# Altium schematic sheet ICWSHLP2_Head_2x2_ASIC2.SchDoc(ICWSHLP2_Head_2x2_ASIC2)
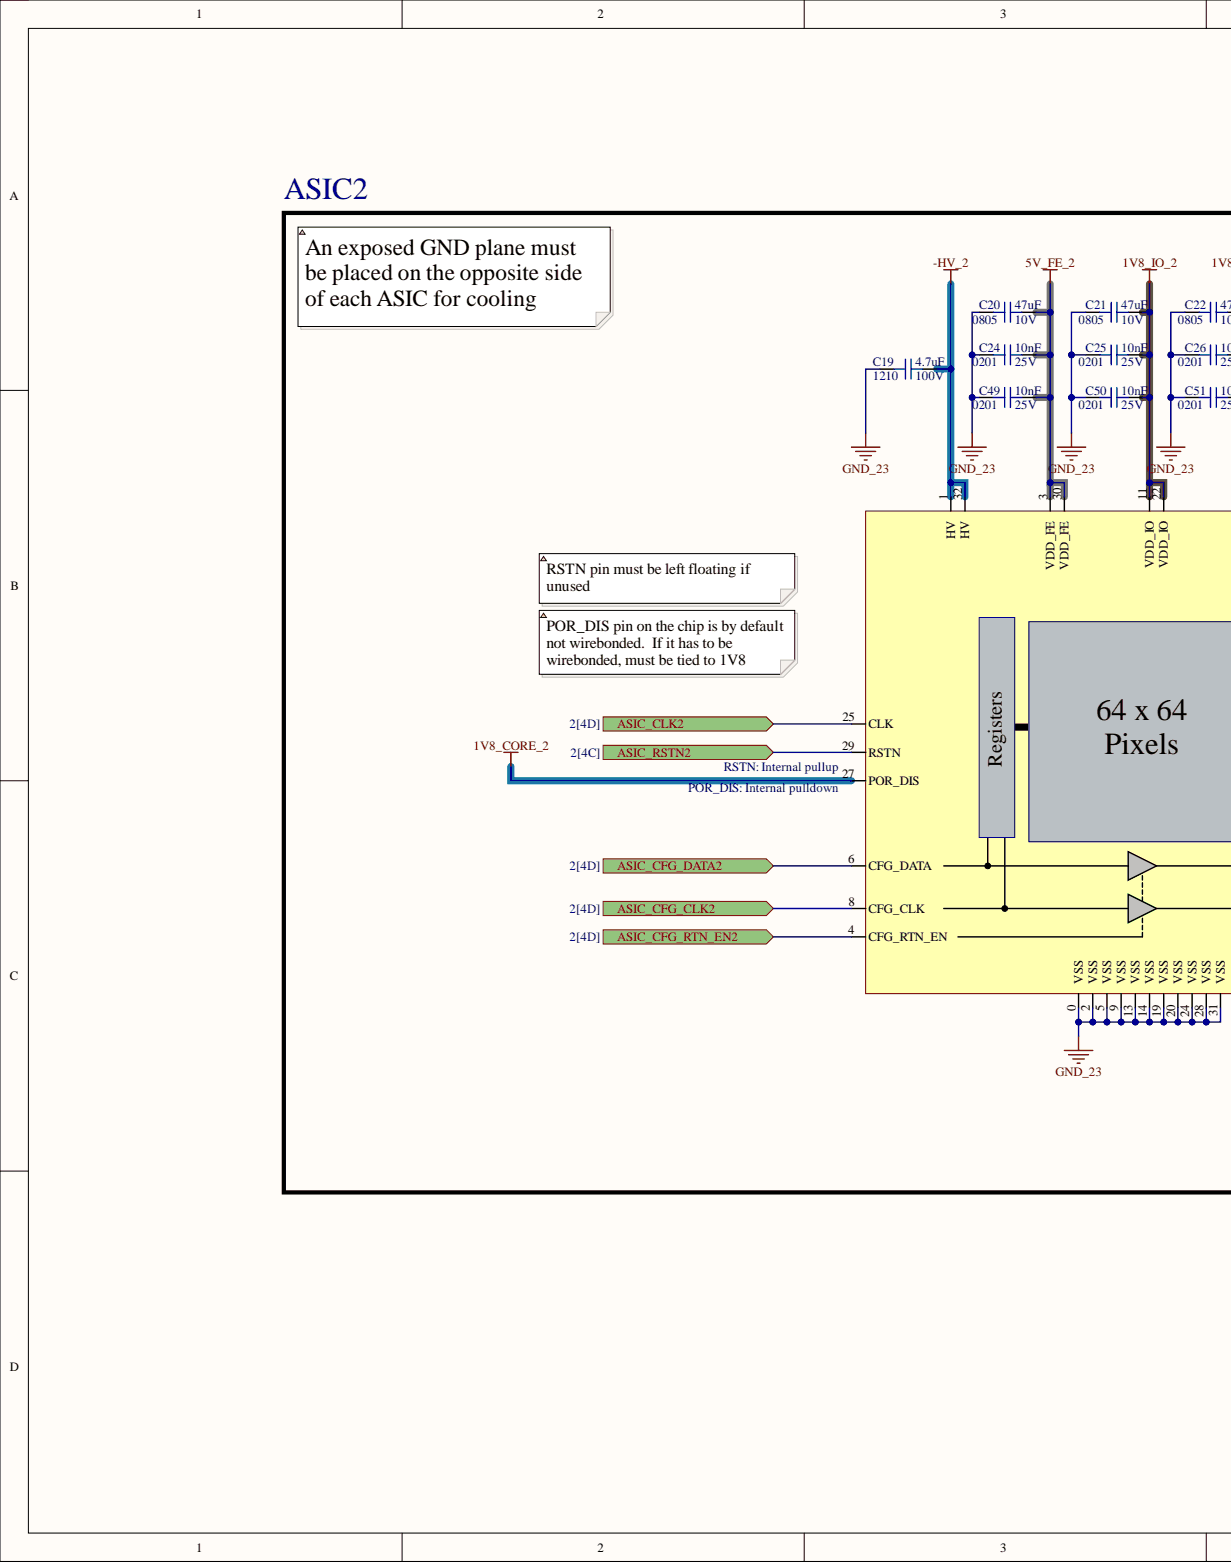
[diagram p0: ICWSHLP2_Head_2x2_ASIC2.SchDoc, left]
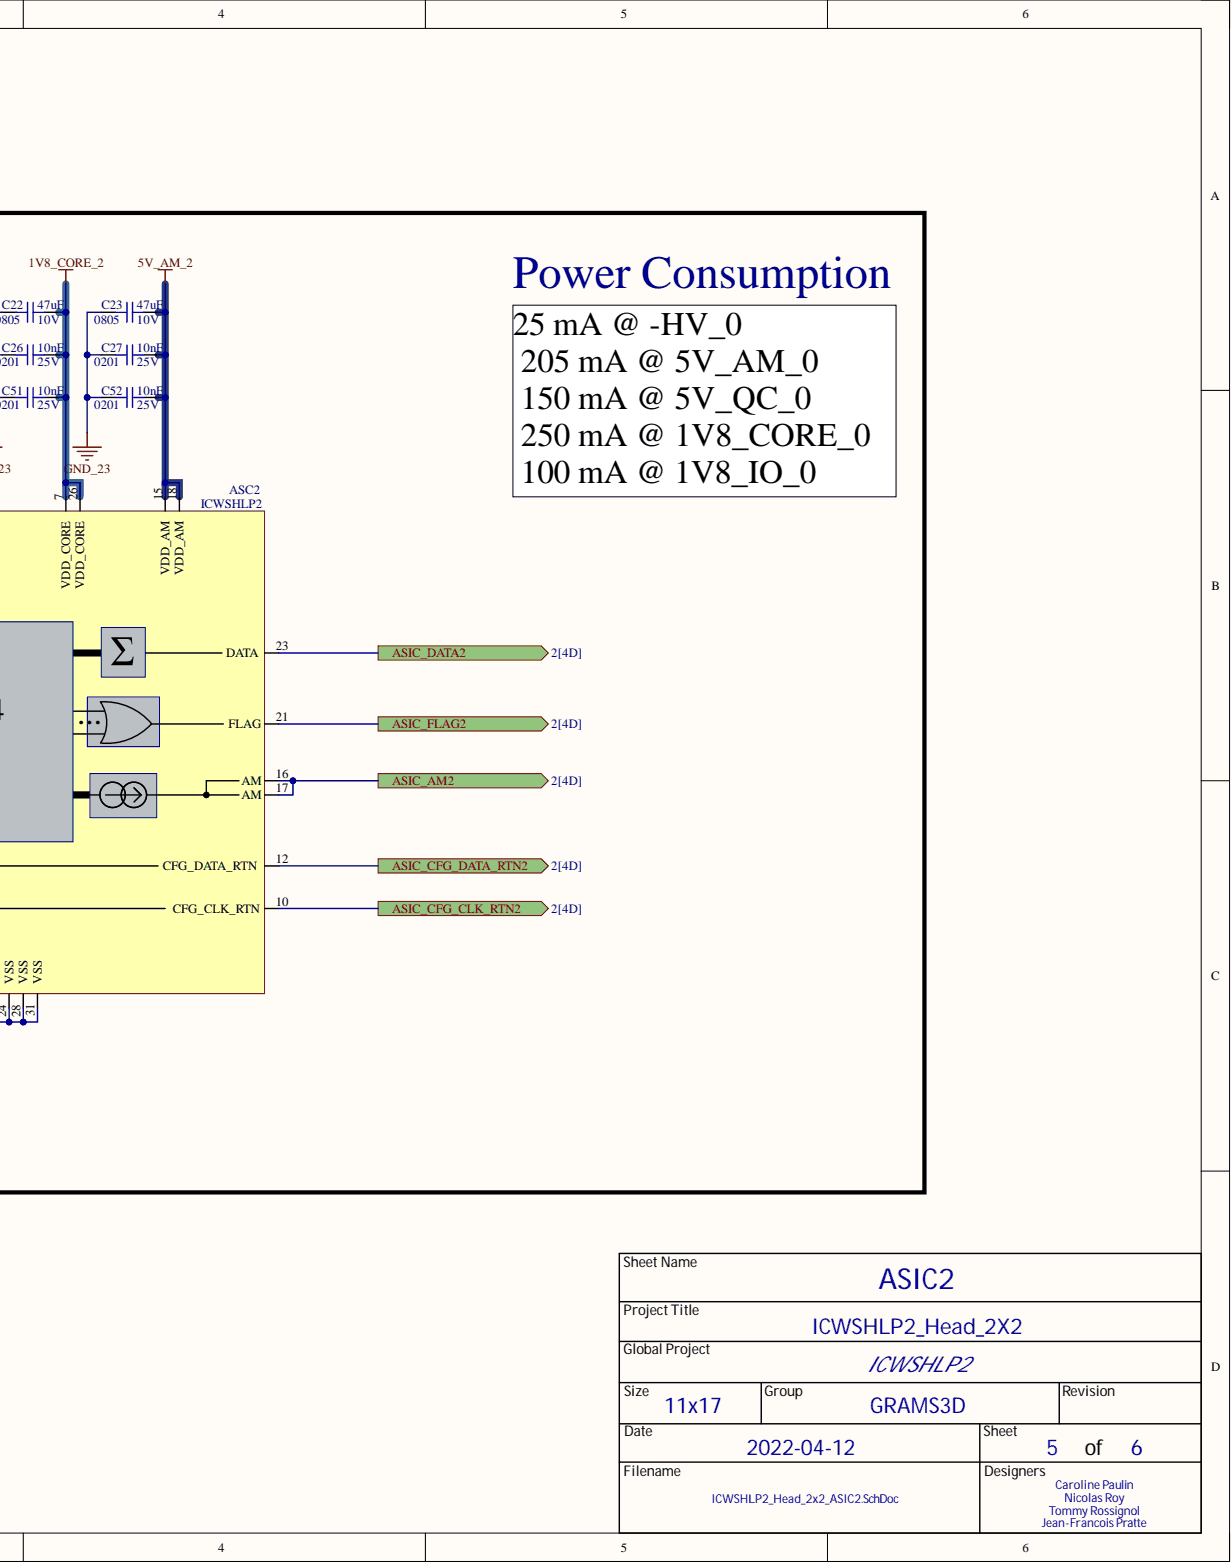
[diagram p1: ICWSHLP2_Head_2x2_ASIC2.SchDoc, right]

## Parts
ASC2  ICWSHLP2  [ASC_ICWSHLP2]
C19  value 4.7uF  Package: 1210  Voltage Rating: 100V  [CAP_CER_1210]  (hidden comment: GCM32DC72A475KE02L)
C20  value 47uF  Package: 0805  Voltage Rating: 10V  [CAP_CER_0805]  (hidden comment: GRM21BR61A476ME15L)
C21  value 47uF  Package: 0805  Voltage Rating: 10V  [CAP_CER_0805]  (hidden comment: GRM21BR61A476ME15L)
C22  value 47uF  Package: 0805  Voltage Rating: 10V  [CAP_CER_0805]  (hidden comment: GRM21BR61A476ME15L)
C23  value 47uF  Package: 0805  Voltage Rating: 10V  [CAP_CER_0805]  (hidden comment: GRM21BR61A476ME15L)
C24  value 10nF  Package: 0201  Voltage Rating: 25V  [CAP_CER_0201]  (hidden comment: TMK063B7103KP-F)
C25  value 10nF  Package: 0201  Voltage Rating: 25V  [CAP_CER_0201]  (hidden comment: TMK063B7103KP-F)
C26  value 10nF  Package: 0201  Voltage Rating: 25V  [CAP_CER_0201]  (hidden comment: TMK063B7103KP-F)
C27  value 10nF  Package: 0201  Voltage Rating: 25V  [CAP_CER_0201]  (hidden comment: TMK063B7103KP-F)
C49  value 10nF  Package: 0201  Voltage Rating: 25V  [CAP_CER_0201]  (hidden comment: TMK063B7103KP-F)
C50  value 10nF  Package: 0201  Voltage Rating: 25V  [CAP_CER_0201]  (hidden comment: TMK063B7103KP-F)
C51  value 10nF  Package: 0201  Voltage Rating: 25V  [CAP_CER_0201]  (hidden comment: TMK063B7103KP-F)
C52  value 10nF  Package: 0201  Voltage Rating: 25V  [CAP_CER_0201]  (hidden comment: TMK063B7103KP-F)

## Ports
ASIC_AM2, ASIC_CFG_CLK2, ASIC_CFG_CLK_RTN2, ASIC_CFG_DATA2, ASIC_CFG_DATA_RTN2, ASIC_CFG_RTN_EN2, ASIC_CLK2, ASIC_DATA2, ASIC_FLAG2, ASIC_RSTN2

## Nets
1V8_CORE_2: ASC2.7(VDD_CORE) ASC2.26(VDD_CORE) ASC2.27(POR_DIS) C22.2 C26.1 C51.1
1V8_IO_2: ASC2.11(VDD_IO) ASC2.22(VDD_IO) C21.2 C25.1 C50.1
5V_AM_2: ASC2.15(VDD_AM) ASC2.18(VDD_AM) C23.2 C27.1 C52.1
5V_FE_2: ASC2.3(VDD_FE) ASC2.30(VDD_FE) C20.2 C24.1 C49.1
-HV_2: ASC2.1(HV) ASC2.32(HV) C19.1
GND_23: ASC2.0(VSS) ASC2.2(VSS) ASC2.5(VSS) ASC2.9(VSS) ASC2.13(VSS) ASC2.14(VSS) ASC2.19(VSS) ASC2.20(VSS) ASC2.24(VSS) ASC2.28(VSS) ASC2.31(VSS) C19.2 C20.1 C21.1 C22.1 C23.1 C24.2 C25.2 C26.2 C27.2 C49.2 C50.2 C51.2 C52.2
ASIC_AM2: ASC2.16(AM) ASC2.17(AM) ; port ASIC_AM2
ASIC_CFG_CLK2: ASC2.8(CFG_CLK) ; port ASIC_CFG_CLK2
ASIC_CFG_CLK_RTN2: ASC2.10(CFG_CLK_RTN) ; port ASIC_CFG_CLK_RTN2
ASIC_CFG_DATA2: ASC2.6(CFG_DATA) ; port ASIC_CFG_DATA2
ASIC_CFG_DATA_RTN2: ASC2.12(CFG_DATA_RTN) ; port ASIC_CFG_DATA_RTN2
ASIC_CFG_RTN_EN2: ASC2.4(CFG_RTN_EN) ; port ASIC_CFG_RTN_EN2
ASIC_CLK2: ASC2.25(CLK) ; port ASIC_CLK2
ASIC_DATA2: ASC2.23(DATA) ; port ASIC_DATA2
ASIC_FLAG2: ASC2.21(FLAG) ; port ASIC_FLAG2
ASIC_RSTN2: ASC2.29(RSTN) ; port ASIC_RSTN2

## Library data of the parts
ASC2 = ASC_ICWSHLP2: Rev 2. CMOS SPAD Readout for 3D PDC in Low Power Applications.; Manufacturer #1: TSMC 180 nm BCD; GRAMS Lib Spec: 2.7; Magnetic Material: No; Polarized: Yes; Voltage Rating: 1.8V/5.0V; Assembly Type: Wirebonding; Component Kind: Standard; Library Name: GRAMS_MASTER_LIB_SCH.SchLib; Package: Bare Die; Part Number #1: ICWSHLP2; Supplier 1: TSMC; Supplier Part Number 1: ICWSHLP2; Temperature: -200 to 125 degC; CreatedBy/Date: Nicolas Roy/24 sept. 2018; VerifiedBy: Nicolas Roy
C19 = CAP_CER_GCM32DC72A475KE02L: CAP CER 4.7UF 100V X7S 1210; Manufacturer #1: Murata Electronics; Cryo: UNKNOWN; GRAMS Lib Spec: 2.8; Polarized: NO; Tolerance: 5%; Assembly Type: SMD; Component Kind: Standard; HelpURL: https://search.murata.co.jp/Ceramy/image/img/A01X/G101/ENG/GCM32DC72A475KE02-01.pdf; Magnetic Material: UNKNOWN; Part Number #1: GCM32DC72A475KE02L; Solder Paste Type: UNKNOWN; Stencil Thickness (Recommended): UNKNOWN; Supplier 1: Digi-Key; Supplier Part Number 1: 490-14484-1-ND; Temperature: -55°C ~ 125°C; CreatedBy/Date: Thomas G-C 2021-07-01; Library Name: Lib_temp_Thomas_GC.SchLib
C20-C23 = CAP_CER_GRM21BR61A476ME15L: CAP - CER 47uF 20% 10V X5R - 0805; Manufacturer #1: Murata; Cryo: UNKNOWN; GRAMS Lib Spec: 2.8; Polarized: No; Assembly Type: SMD; Component Kind: Standard; HelpURL: http://search.murata.co.jp/Ceramy/image/img/A01X/G101/ENG/GRM21BR61A476ME15-01.pdf; Library Name: GRAMS_MASTER_LIB_SCH.SchLib; Magnetic Material: UNKNOWN; Part Number #1: GRM21BR61A476ME15L; Solder Paste Type: UNKNOWN; Stencil Thickness (Recommended): UNKNOWN; Supplier 1: Digi-Key; Supplier Part Number 1: 490-9961-1-ND; Temperature: -55°C ~ 85°C; Tolerance: 20%; CreatedBy/Date: Joel Lemay 2018-06-19; VerifiedBy: Caroline Paulin - 7 sept 2018
C24-C27 C49-C52 = CAP_CER_TMK063B7103KP-F: CAP - CER 10nF 10% 25V X7R - 0201; Manufacturer #1: Taiyo Yuden; Cryo: UNKNOWN; GRAMS Lib Spec: 2.8; Polarized: No; Solder Paste Type: TYPE 3; Stencil Thickness (Recommended): MAX 5 MILS; Assembly Type: SMD; Component Kind: Standard; HelpURL: http://ds.yuden.co.jp/TYCOMPAS/ut/detail.do?productNo=TMK063B7103KP-F&fileName=TMK063B7103KP-F_SS&mode=specSheetDownload; Library Name: GRAMS_MASTER_LIB_SCH.SchLib; Magnetic Material: UNKNOWN; Part Number #1: TMK063B7103KP-F; Supplier 1: Digi-Key; Supplier Part Number 1: 587-5943-1-ND; Temperature: -55°C ~ 125°C; Tolerance: 10%; CreatedBy/Date: Nicolas Roy - 13 dec. 2018; VerifiedBy: Nicolas V. (2019-01-22)
